# Altium schematic sheet BUS_Switch.SchDoc
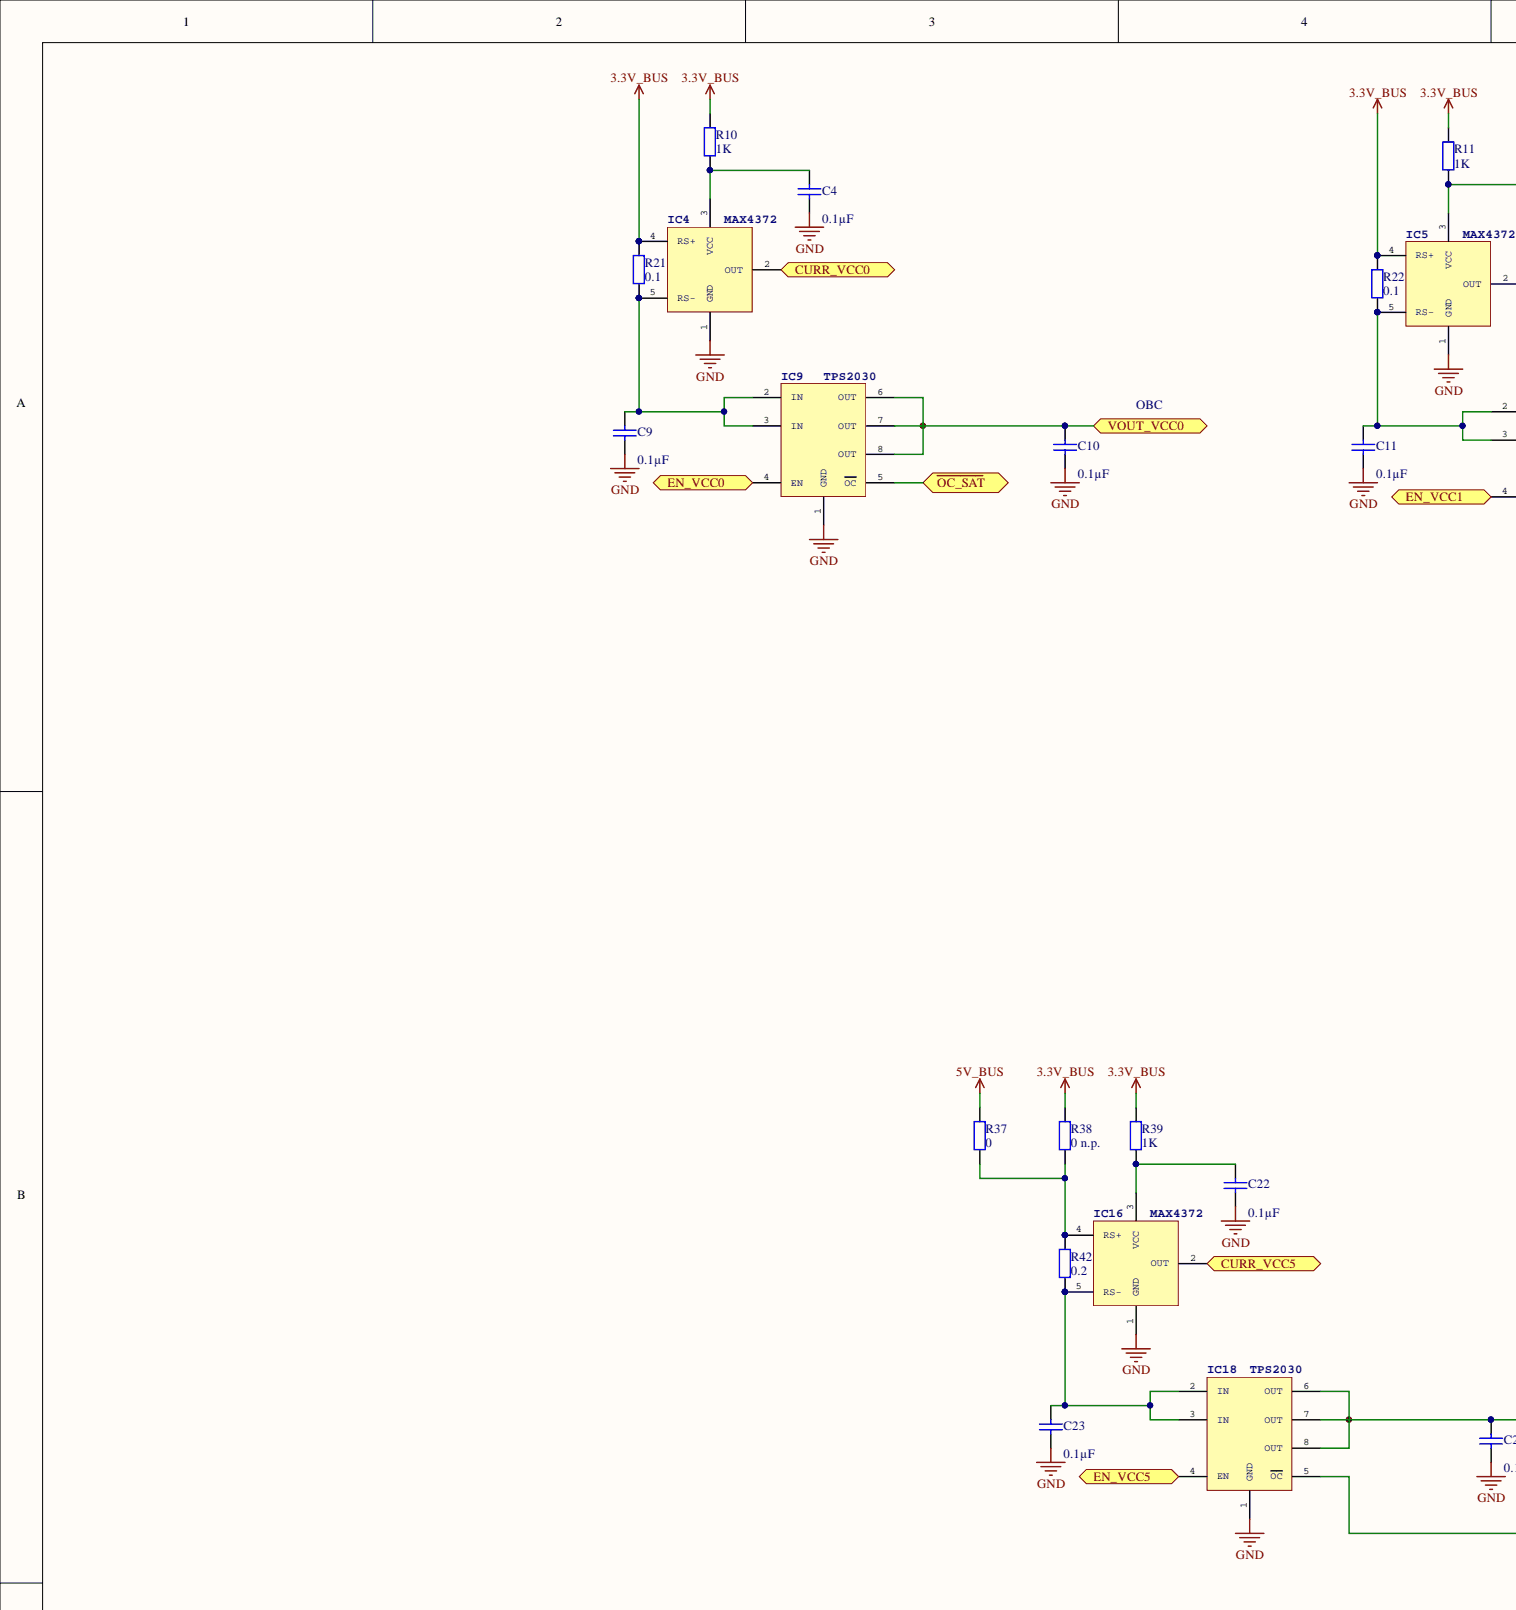
[diagram p0: BUS_Switch.SchDoc, top left]
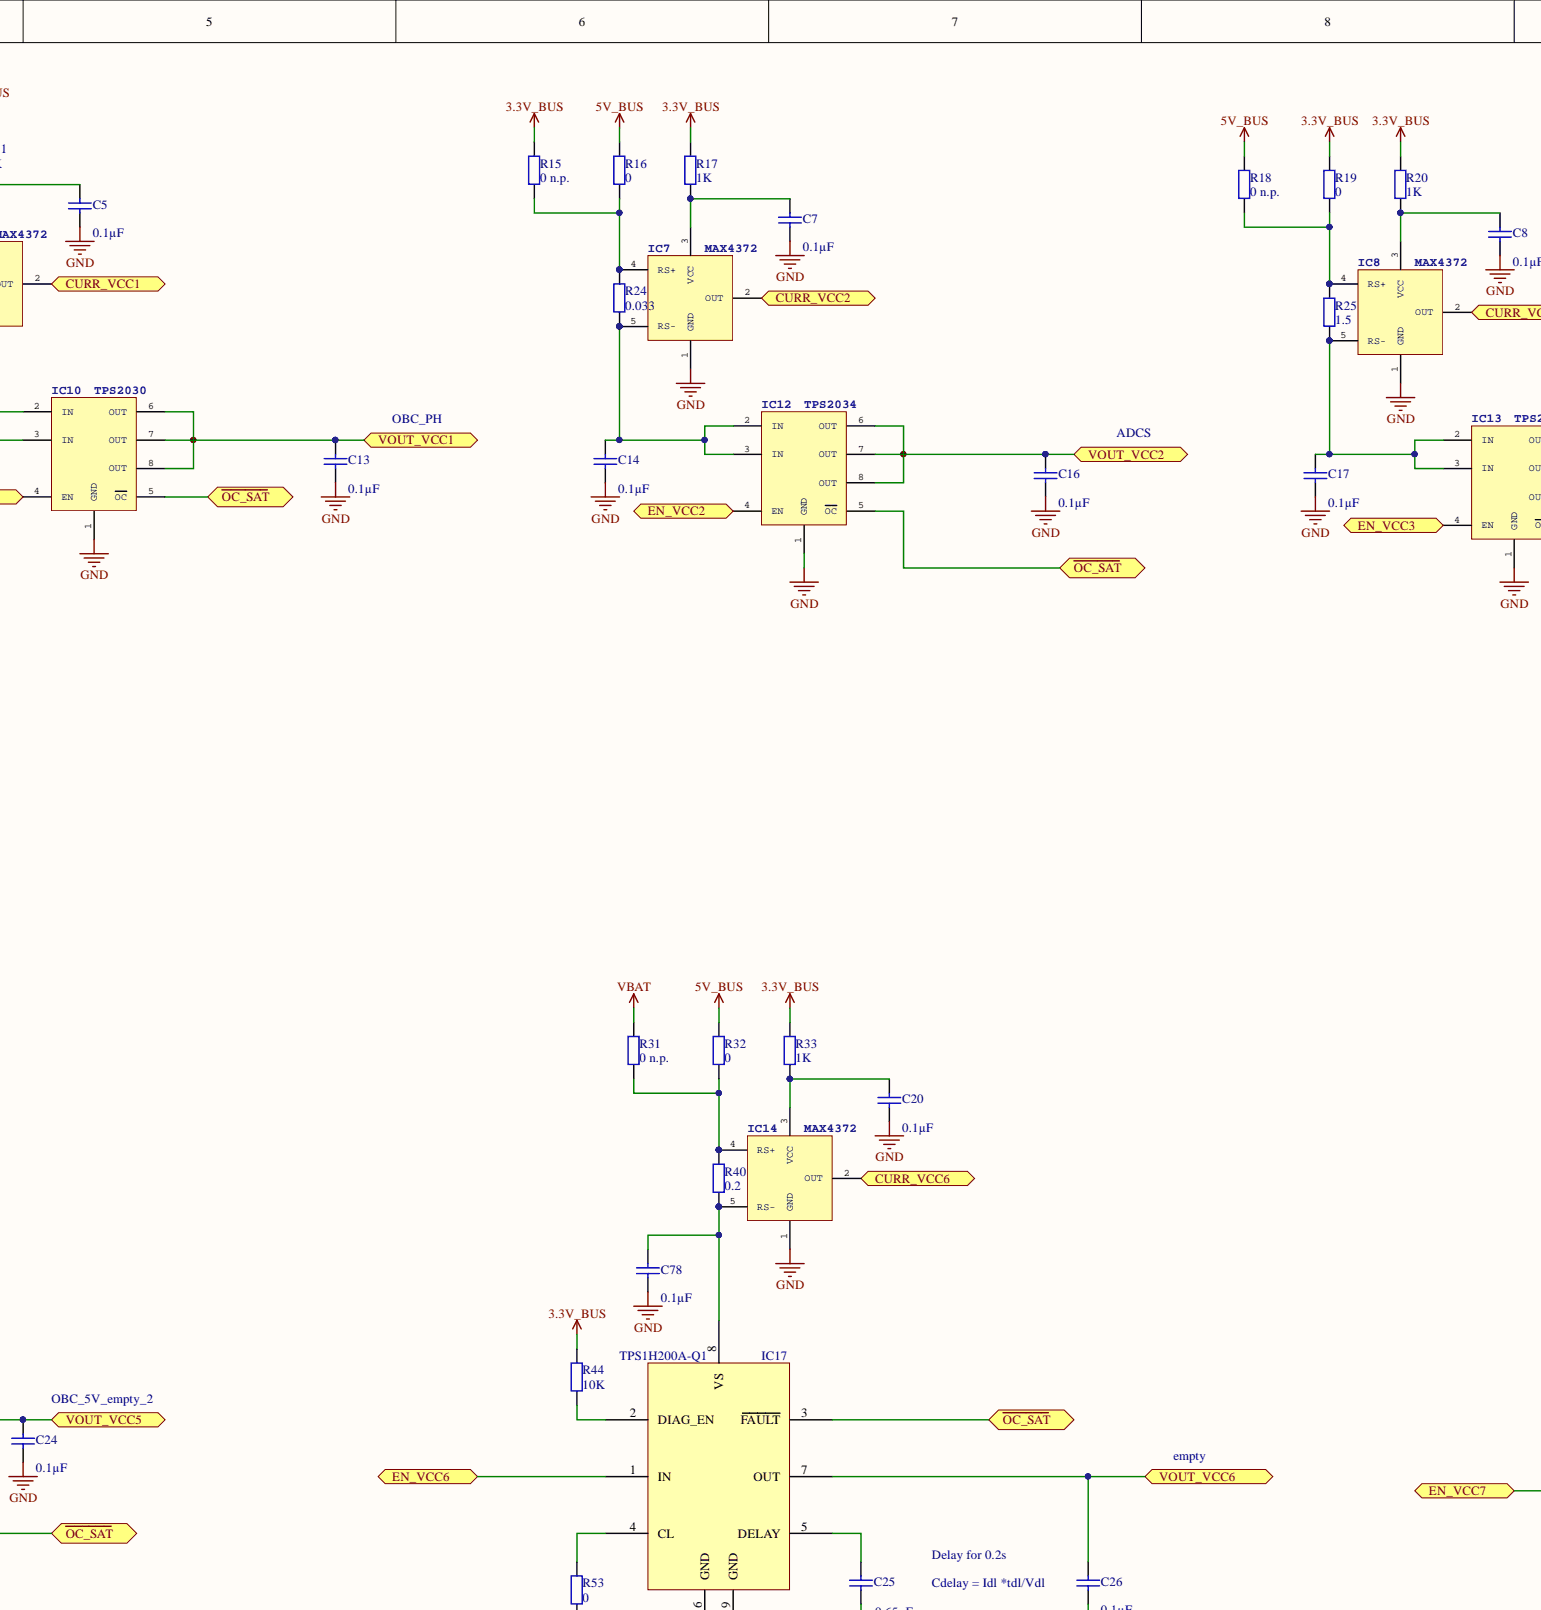
[diagram p1: BUS_Switch.SchDoc, top centre]
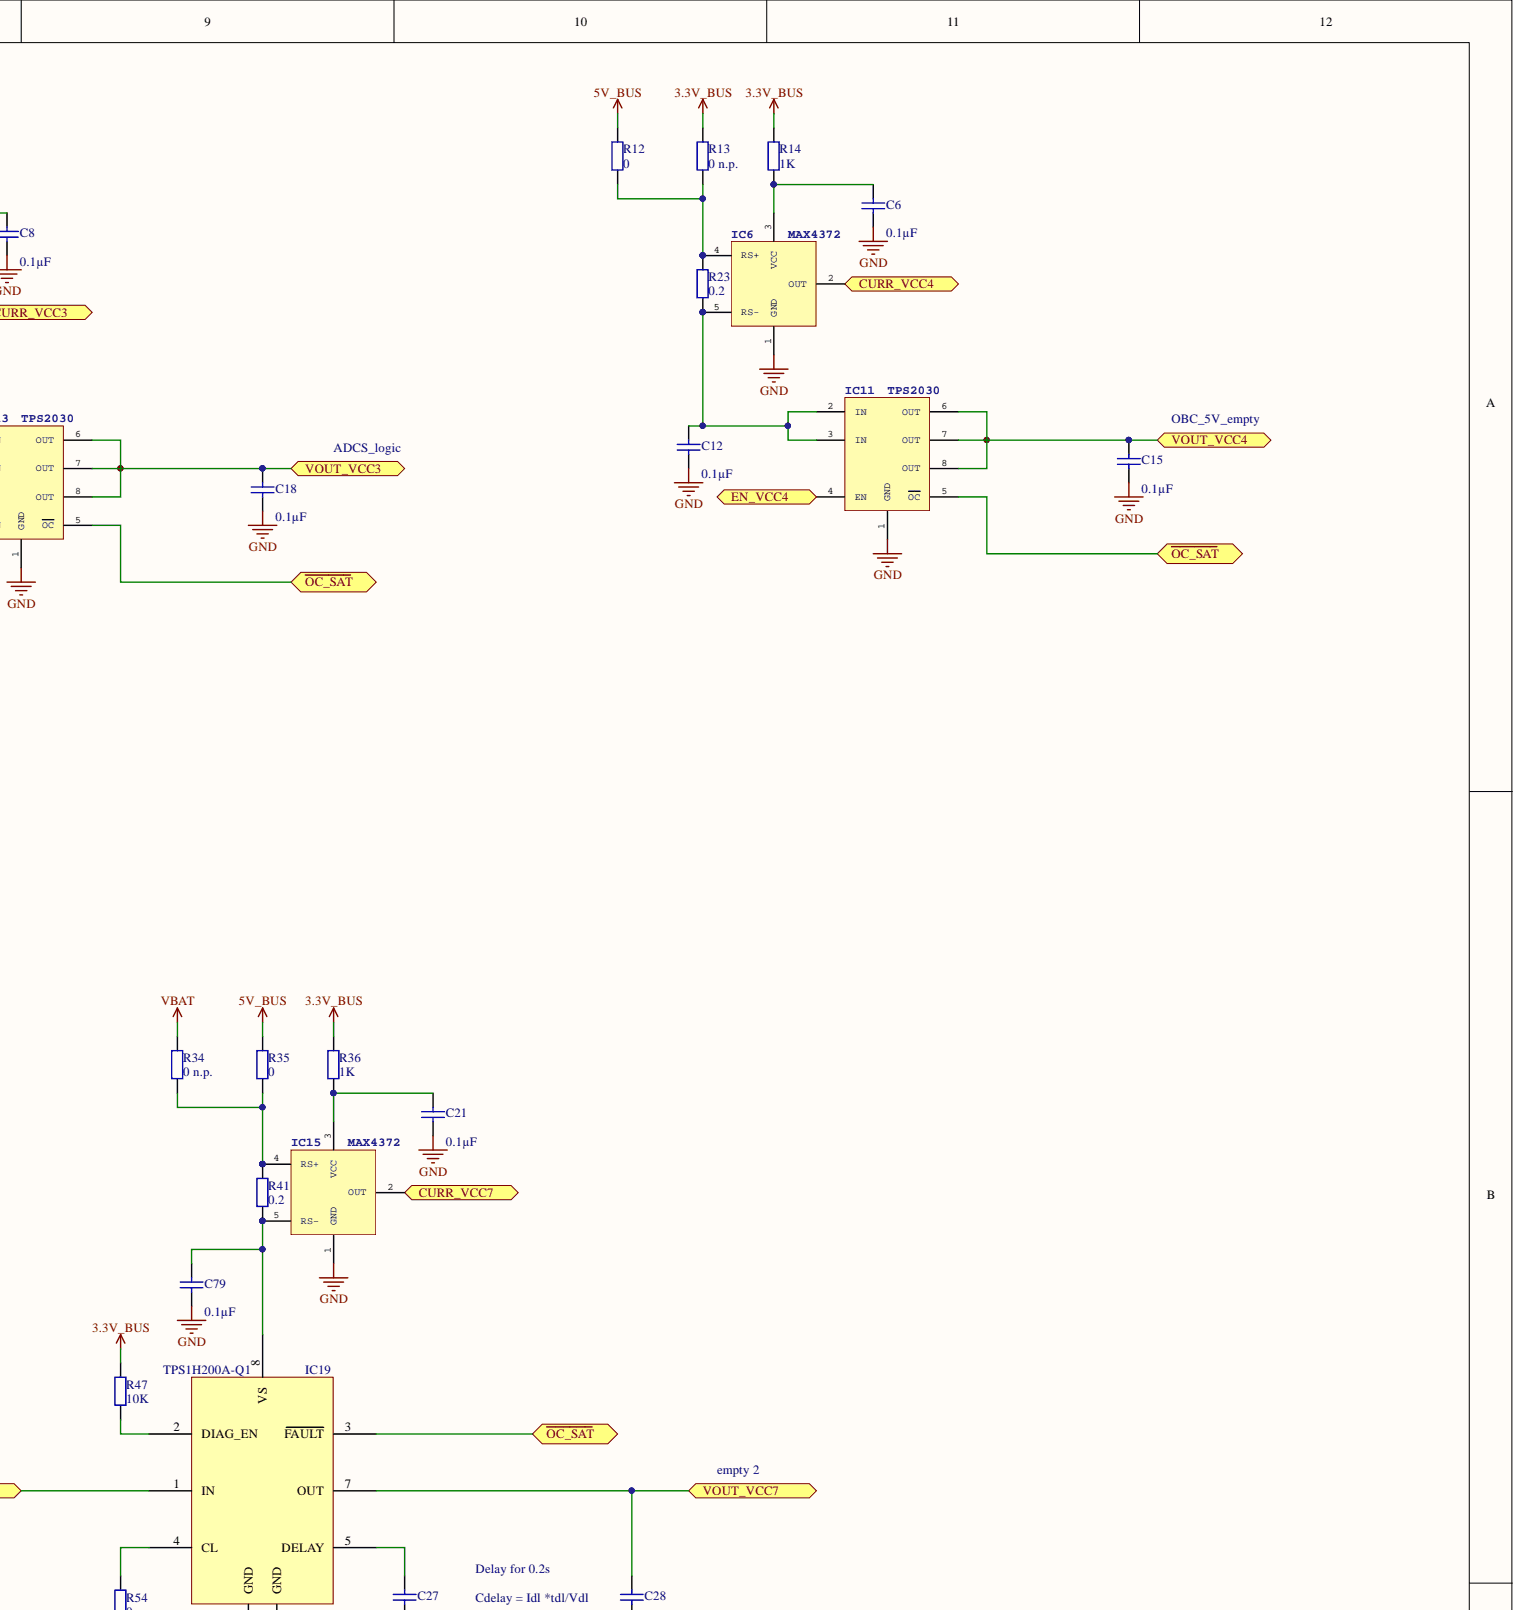
[diagram p2: BUS_Switch.SchDoc, top right]
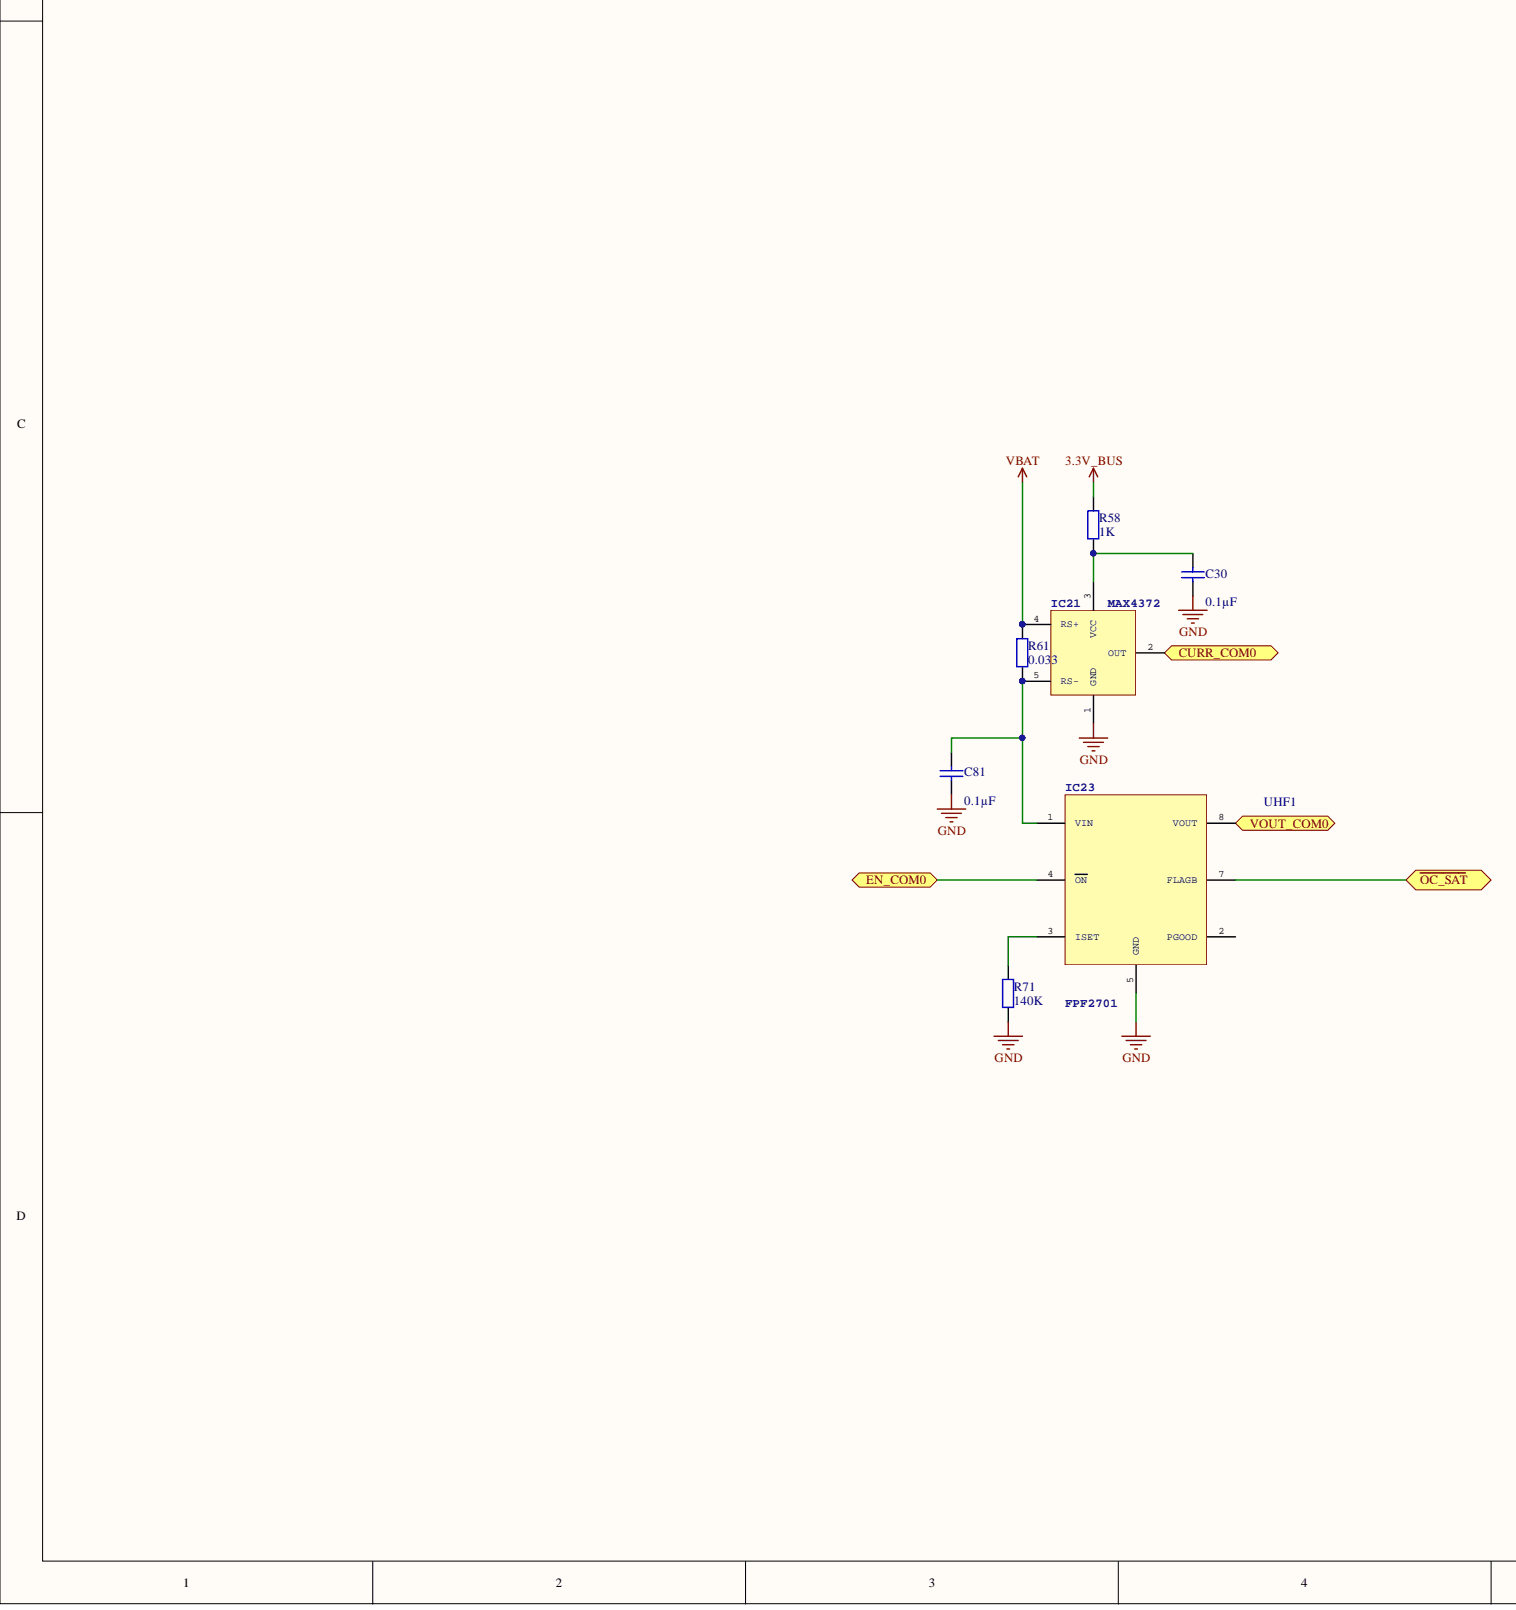
[diagram p3: BUS_Switch.SchDoc, bottom left]
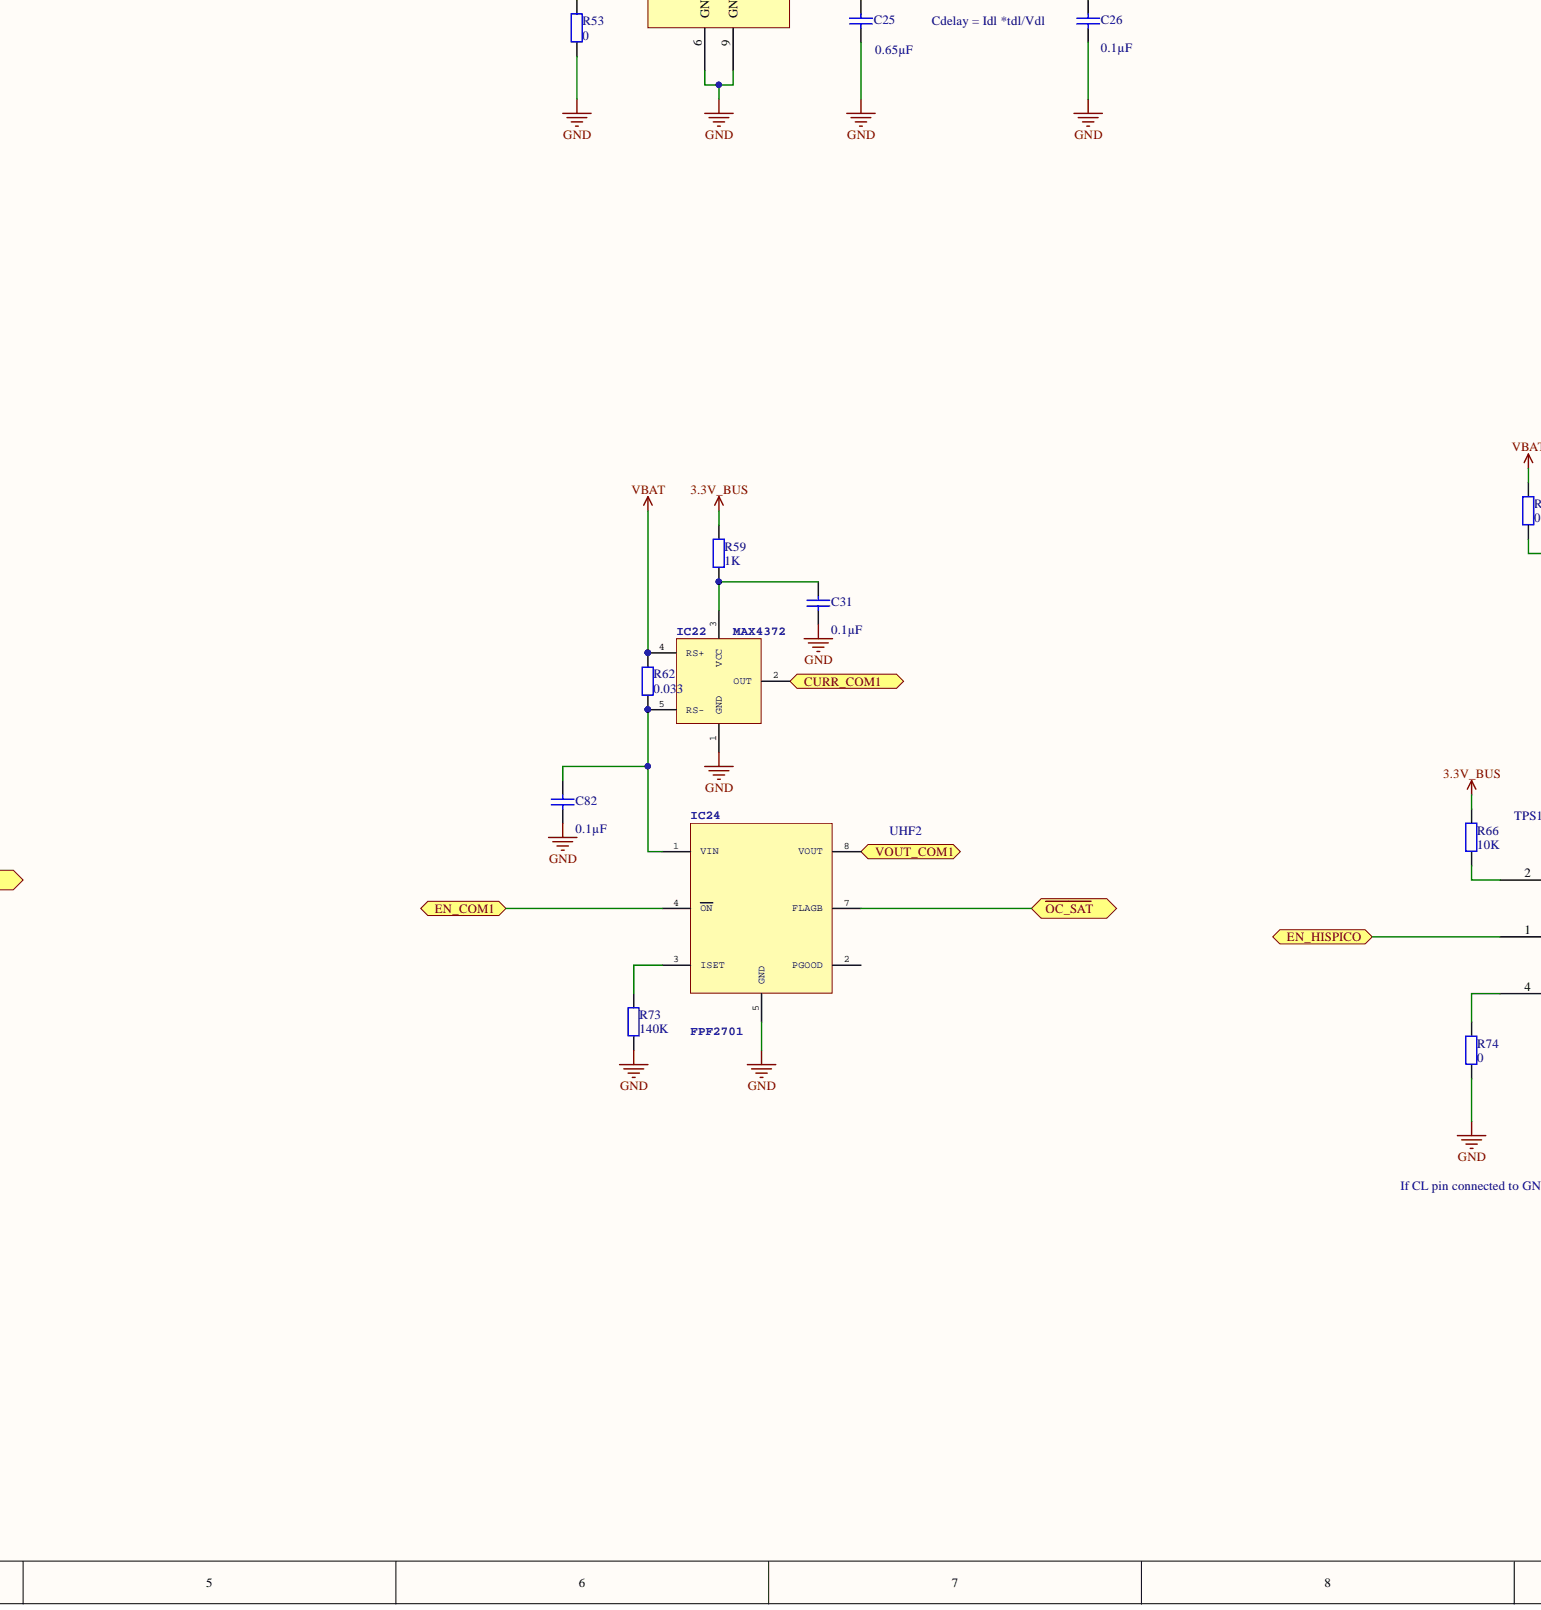
[diagram p4: BUS_Switch.SchDoc, bottom centre]
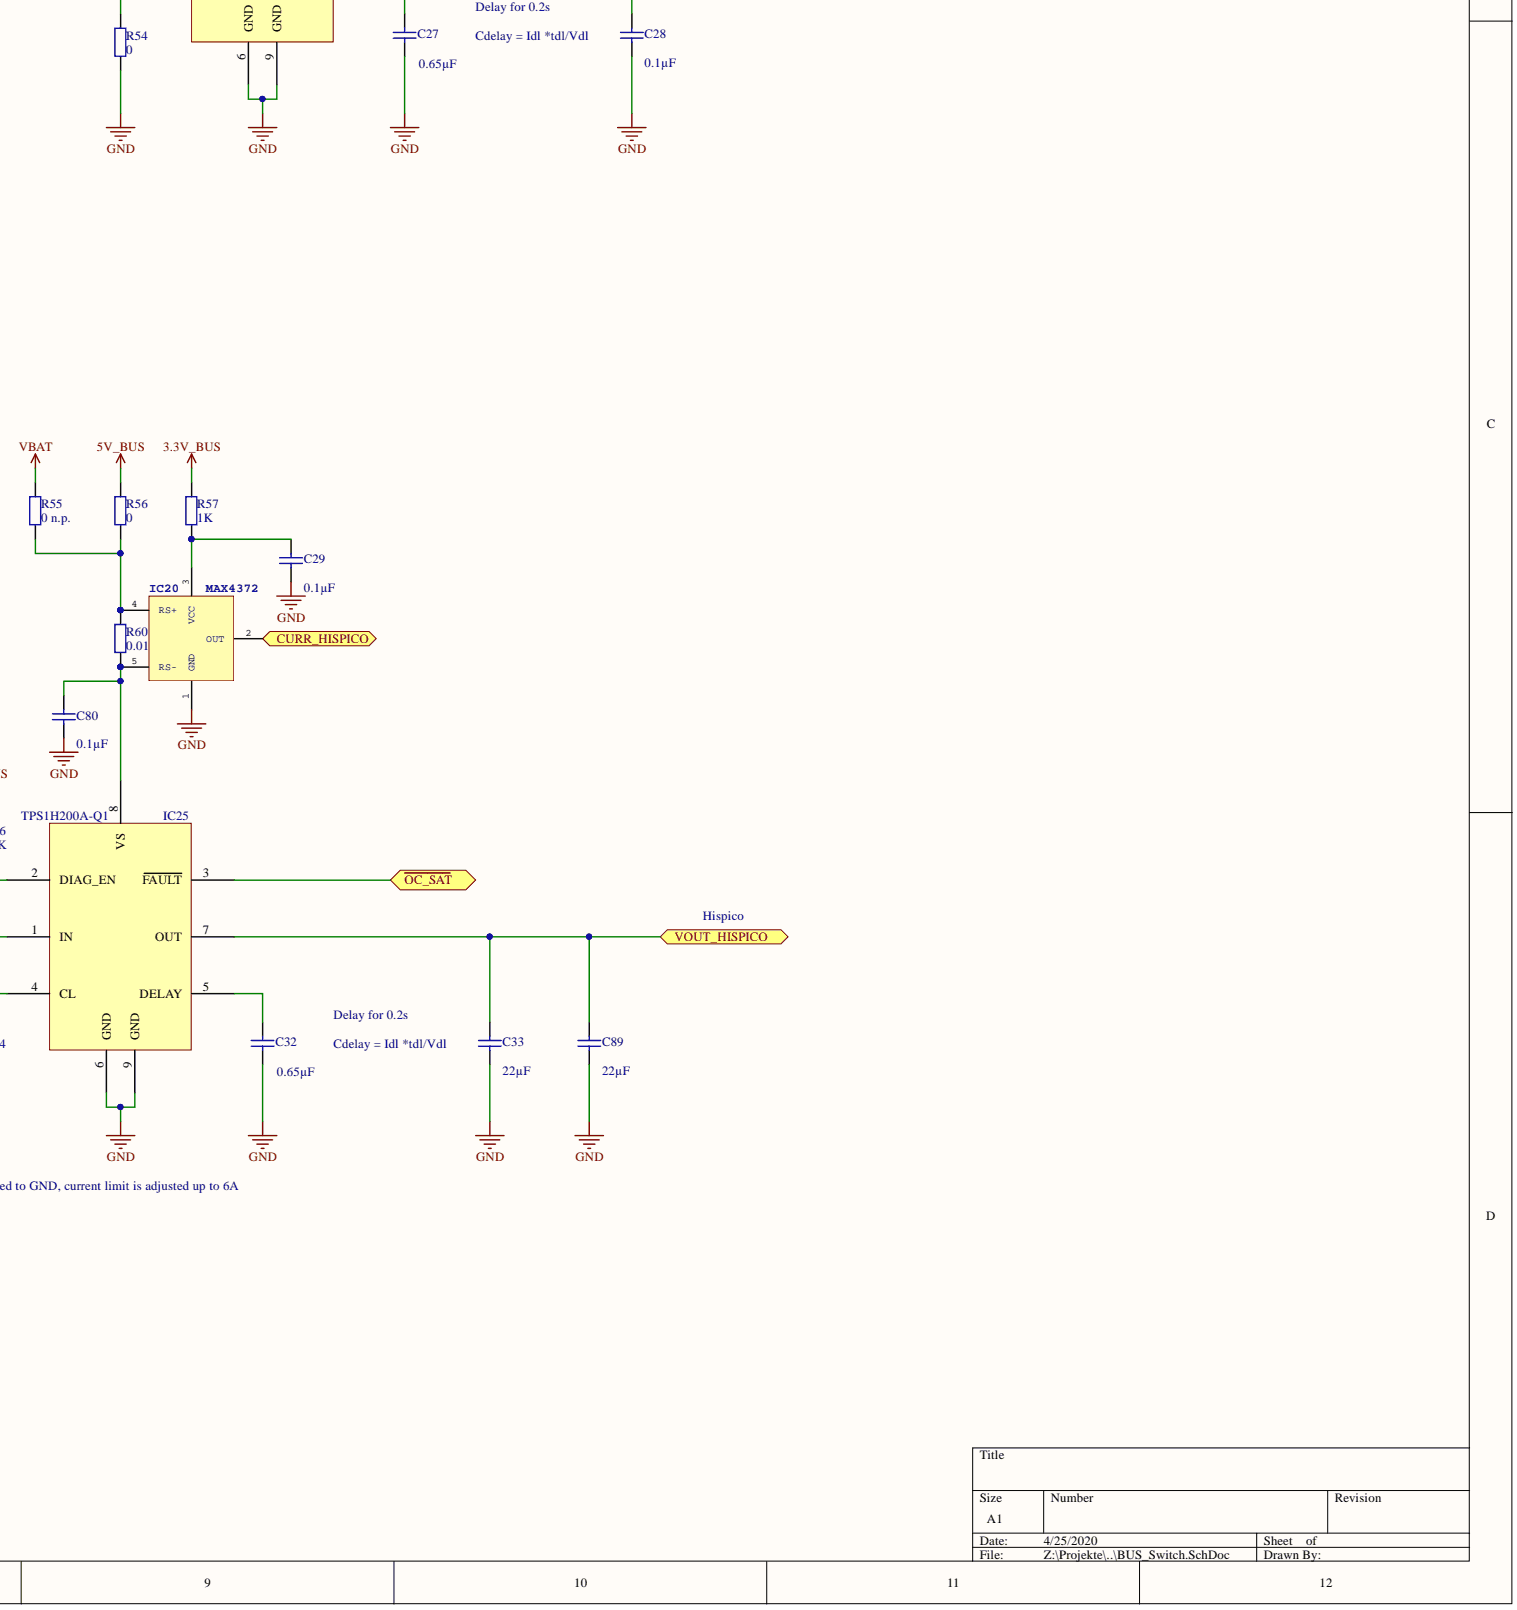
[diagram p5: BUS_Switch.SchDoc, bottom right]

## Parts
C4  0.1µF  [1608[0603]]
C5  0.1µF  [1608[0603]]
C6  0.1µF  [1608[0603]]
C7  0.1µF  [1608[0603]]
C8  0.1µF  [1608[0603]]
C9  0.1µF  [1608[0603]]
C10  0.1µF  [1608[0603]]
C11  0.1µF  [1608[0603]]
C12  0.1µF  [1608[0603]]
C13  0.1µF  [1608[0603]]
C14  0.1µF  [1608[0603]]
C15  0.1µF  [1608[0603]]
C16  0.1µF  [1608[0603]]
C17  0.1µF  [1608[0603]]
C18  0.1µF  [1608[0603]]
C20  0.1µF  [1608[0603]]
C21  0.1µF  [1608[0603]]
C22  0.1µF  [1608[0603]]
C23  0.1µF  [1608[0603]]
C24  0.1µF  [1608[0603]]
C25  0.65µF  [1608[0603]]
C26  0.1µF  [1608[0603]]
C27  0.65µF  [1608[0603]]
C28  0.1µF  [1608[0603]]
C29  0.1µF  [1608[0603]]
C30  0.1µF  [1608[0603]]
C31  0.1µF  [1608[0603]]
C32  0.65µF  [1608[0603]]
C33  value 22µF  [6-0805_N]  (hidden comment: 100µF)
C78  0.1µF  [1608[0603]]
C79  0.1µF  [1608[0603]]
C80  0.1µF  [1608[0603]]
C81  0.1µF  [1608[0603]]
C82  0.1µF  [1608[0603]]
C89  value 22µF  [6-0805_N]  (hidden comment: 100µF)
IC4  MAX4372  [SOT23-5L]
IC5  MAX4372  [SOT23-5L]
IC6  MAX4372  [SOT23-5L]
IC7  MAX4372  [SOT23-5L]
IC8  MAX4372  [SOT23-5L]
IC9  TPS2030  [SO08]
IC10  TPS2030  [SO08]
IC11  TPS2030  [SO08]
IC12  TPS2034  [SO08]
IC13  TPS2030  [SO08]
IC14  MAX4372  [SOT23-5L]
IC15  MAX4372  [SOT23-5L]
IC16  MAX4372  [SOT23-5L]
IC17  TPS1H200A-Q1  [SON65P300X100_HS-9N_SW]
IC18  TPS2030  [SO08]
IC19  TPS1H200A-Q1  [SON65P300X100_HS-9N_SW]
IC20  MAX4372  [SOT23-5L]
IC21  MAX4372  [SOT23-5L]
IC22  MAX4372  [SOT23-5L]
IC23  FPF2701  [SO08]
IC24  FPF2701  [SO08]
IC25  TPS1H200A-Q1  [SON65P300X100_HS-9N_SW]
R10  1K  [1608[0603]]
R11  1K  [1608[0603]]
R12  0  [6-0805_N]
R13  0 n.p.  [6-0805_N]
R14  1K  [1608[0603]]
R15  0 n.p.  [6-0805_N]
R16  0  [6-0805_N]
R17  1K  [1608[0603]]
R18  0 n.p.  [6-0805_N]
R19  0  [6-0805_N]
R20  1K  [1608[0603]]
R21  0.1  [1206]
R22  0.1  [1206]
R23  0.2  [1206]
R24  0.033  [1206]
R25  1.5  [1206]
R31  0 n.p.  [6-0805_N]
R32  0  [6-0805_N]
R33  1K  [1608[0603]]
R34  0 n.p.  [6-0805_N]
R35  0  [6-0805_N]
R36  1K  [1608[0603]]
R37  0  [6-0805_N]
R38  0 n.p.  [6-0805_N]
R39  1K  [1608[0603]]
R40  0.2  [1206]
R41  0.2  [1206]
R42  0.2  [1206]
R44  10K  [1608[0603]]
R47  10K  [1608[0603]]
R53  0  [1608[0603]]
R54  0  [1608[0603]]
R55  0 n.p.  [6-0805_N]
R56  0  [6-0805_N]
R57  1K  [1608[0603]]
R58  1K  [1608[0603]]
R59  1K  [1608[0603]]
R60  value 0.01  [1206]  (hidden comment: 0.07)
R61  value 0.033  [1206]  (hidden comment: 0.15)
R62  value 0.033  [1206]  (hidden comment: 0.15)
R66  10K  [1608[0603]]
R71  140K  [1608[0603]]
R73  140K  [1608[0603]]
R74  0  [1608[0603]]

## Ports
CURR_COM0, CURR_COM1, CURR_HISPICO, CURR_VCC0, CURR_VCC1, CURR_VCC2, CURR_VCC3, CURR_VCC4, CURR_VCC5, CURR_VCC6, CURR_VCC7, EN_COM0, EN_COM1, EN_HISPICO, EN_VCC0, EN_VCC1, EN_VCC2, EN_VCC3, EN_VCC4, EN_VCC5, EN_VCC6, EN_VCC7, VOUT_COM0, VOUT_COM1, VOUT_HISPICO, VOUT_VCC0, VOUT_VCC1, VOUT_VCC2, VOUT_VCC3, VOUT_VCC4, VOUT_VCC5, VOUT_VCC6, VOUT_VCC7, ~{OC_SAT}

## Nets
3.3V_BUS: IC4.4(RS+) IC5.4(RS+) R10.2 R11.2 R13.2 R14.2 R15.2 R17.2 R19.2 R20.2 R21.2 R22.2 R33.2 R36.2 R38.2 R39.2 R44.1 R47.1 R57.2 R58.2 R59.2 R66.1
5V_BUS: R12.2 R16.2 R18.2 R32.2 R35.2 R37.2 R56.2
GND: C4.1 C5.1 C6.1 C7.1 C8.1 C9.1 C10.1 C11.1 C12.1 C13.1 C14.1 C15.1 C16.1 C17.1 C18.1 C20.1 C21.1 C22.1 C23.1 C24.1 C25.1 C26.1 C27.1 C28.1 C29.1 C30.1 C31.1 C32.1 C33.1 C78.1 C79.1 C80.1 C81.1 C82.1 C89.1 IC4.1(GND) IC5.1(GND) IC6.1(GND) IC7.1(GND) IC8.1(GND) IC9.1(GND) IC10.1(GND) IC11.1(GND) IC12.1(GND) IC13.1(GND) IC14.1(GND) IC15.1(GND) IC16.1(GND) IC17.6(GND) IC17.9(GND) IC18.1(GND) IC19.6(GND) IC19.9(GND) IC20.1(GND) IC21.1(GND) IC22.1(GND) IC23.5(GND) IC24.5(GND) IC25.6(GND) IC25.9(GND) R53.1 R54.1 R71.1 R73.1 R74.1
VBAT: IC21.4(RS+) IC22.4(RS+) R31.2 R34.2 R55.2 R61.2 R62.2
CURR_COM0: IC21.2(OUT) ; port CURR_COM0
CURR_COM1: IC22.2(OUT) ; port CURR_COM1
CURR_HISPICO: IC20.2(OUT) ; port CURR_HISPICO
CURR_VCC0: IC4.2(OUT) ; port CURR_VCC0
CURR_VCC1: IC5.2(OUT) ; port CURR_VCC1
CURR_VCC2: IC7.2(OUT) ; port CURR_VCC2
CURR_VCC3: IC8.2(OUT) ; port CURR_VCC3
CURR_VCC4: IC6.2(OUT) ; port CURR_VCC4
CURR_VCC5: IC16.2(OUT) ; port CURR_VCC5
CURR_VCC6: IC14.2(OUT) ; port CURR_VCC6
CURR_VCC7: IC15.2(OUT) ; port CURR_VCC7
EN_COM0: IC23.4(ON) ; port EN_COM0
EN_COM1: IC24.4(ON) ; port EN_COM1
EN_HISPICO: IC25.1(IN) ; port EN_HISPICO
EN_VCC0: IC9.4(EN) ; port EN_VCC0
EN_VCC1: IC10.4(EN) ; port EN_VCC1
EN_VCC2: IC12.4(EN) ; port EN_VCC2
EN_VCC3: IC13.4(EN) ; port EN_VCC3
EN_VCC4: IC11.4(EN) ; port EN_VCC4
EN_VCC5: IC18.4(EN) ; port EN_VCC5
EN_VCC6: IC17.1(IN) ; port EN_VCC6
EN_VCC7: IC19.1(IN) ; port EN_VCC7
~{OC_SAT}: IC9.5(OC) IC10.5(OC) IC11.5(OC) IC12.5(OC) IC13.5(OC) IC17.3(FAULT) IC18.5(OC) IC19.3(FAULT) IC23.7(FLAGB) IC24.7(FLAGB) IC25.3(FAULT) ; port ~{OC_SAT}
VOUT_COM0: IC23.8(VOUT) ; port VOUT_COM0
VOUT_COM1: IC24.8(VOUT) ; port VOUT_COM1
VOUT_HISPICO: C33.2 C89.2 IC25.7(OUT) ; port VOUT_HISPICO
VOUT_VCC0: C10.2 IC9.6(OUT) IC9.7(OUT) IC9.8(OUT) ; port VOUT_VCC0
VOUT_VCC1: C13.2 IC10.6(OUT) IC10.7(OUT) IC10.8(OUT) ; port VOUT_VCC1
VOUT_VCC2: C16.2 IC12.6(OUT) IC12.7(OUT) IC12.8(OUT) ; port VOUT_VCC2
VOUT_VCC3: C18.2 IC13.6(OUT) IC13.7(OUT) IC13.8(OUT) ; port VOUT_VCC3
VOUT_VCC4: C15.2 IC11.6(OUT) IC11.7(OUT) IC11.8(OUT) ; port VOUT_VCC4
VOUT_VCC5: C24.2 IC18.6(OUT) IC18.7(OUT) IC18.8(OUT) ; port VOUT_VCC5
VOUT_VCC6: C26.2 IC17.7(OUT) ; port VOUT_VCC6
VOUT_VCC7: C28.2 IC19.7(OUT) ; port VOUT_VCC7
NetC4_2: C4.2 IC4.3(VCC) R10.1
NetC5_2: C5.2 IC5.3(VCC) R11.1
NetC6_2: C6.2 IC6.3(VCC) R14.1
NetC7_2: C7.2 IC7.3(VCC) R17.1
NetC8_2: C8.2 IC8.3(VCC) R20.1
NetC9_2: C9.2 IC4.5(RS-) IC9.2(IN) IC9.3(IN) R21.1
NetC11_2: C11.2 IC5.5(RS-) IC10.2(IN) IC10.3(IN) R22.1
NetC12_2: C12.2 IC6.5(RS-) IC11.2(IN) IC11.3(IN) R23.1
NetC14_2: C14.2 IC7.5(RS-) IC12.2(IN) IC12.3(IN) R24.1
NetC17_2: C17.2 IC8.5(RS-) IC13.2(IN) IC13.3(IN) R25.1
NetC20_2: C20.2 IC14.3(VCC) R33.1
NetC21_2: C21.2 IC15.3(VCC) R36.1
NetC22_2: C22.2 IC16.3(VCC) R39.1
NetC23_2: C23.2 IC16.5(RS-) IC18.2(IN) IC18.3(IN) R42.1
NetC25_2: C25.2 IC17.5(DELAY)
NetC27_2: C27.2 IC19.5(DELAY)
NetC29_2: C29.2 IC20.3(VCC) R57.1
NetC30_2: C30.2 IC21.3(VCC) R58.1
NetC31_2: C31.2 IC22.3(VCC) R59.1
NetC32_2: C32.2 IC25.5(DELAY)
NetC78_2: C78.2 IC14.5(RS-) IC17.8(VS) R40.1
NetC79_2: C79.2 IC15.5(RS-) IC19.8(VS) R41.1
NetC80_2: C80.2 IC20.5(RS-) IC25.8(VS) R60.1
NetC81_2: C81.2 IC21.5(RS-) IC23.1(VIN) R61.1
NetC82_2: C82.2 IC22.5(RS-) IC24.1(VIN) R62.1
NetIC6_4: IC6.4(RS+) R12.1 R13.1 R23.2
NetIC7_4: IC7.4(RS+) R15.1 R16.1 R24.2
NetIC8_4: IC8.4(RS+) R18.1 R19.1 R25.2
NetIC14_4: IC14.4(RS+) R31.1 R32.1 R40.2
NetIC15_4: IC15.4(RS+) R34.1 R35.1 R41.2
NetIC16_4: IC16.4(RS+) R37.1 R38.1 R42.2
NetIC17_2: IC17.2(DIAG_EN) R44.2
NetIC17_4: IC17.4(CL) R53.2
NetIC19_2: IC19.2(DIAG_EN) R47.2
NetIC19_4: IC19.4(CL) R54.2
NetIC20_4: IC20.4(RS+) R55.1 R56.1 R60.2
NetIC23_3: IC23.3(ISET) R71.2
NetIC24_3: IC24.3(ISET) R73.2
NetIC25_2: IC25.2(DIAG_EN) R66.2
NetIC25_4: IC25.4(CL) R74.2

Not connected: IC23 2(PGOOD); IC24 2(PGOOD)

## Library data of the parts
C4-C18 C20-C33 C78-C82 C89 = Cap: Capacitor; Component Kind: Standard; LatestRevisionDate: 17-Jul-2002; LatestRevisionNote: Re-released for DXP Platform.; Library Name: Miscellaneous Devices.IntLib; PackageDescription: Radial Cap, Thru-Hole; 2 Leads; 0.3 in Pin Spacing; PackageReference: RAD-0.3; Published: 8-Jun-2000; Signal Integrity: Cap; Simulation: CAP; Publisher: Altium Limited
  C4-C18 C20-C24 C26 C28-C31 C78-C82: Value: 0.1µF
  C25 C27 C32: Value: 0.65µF
IC9-IC13 IC18 = TPS203*D: Component Kind: Standard; Library Name: EPS.SCHLIB
R10-R25 R31-R42 R44 R47 R53-R62 R66 R71 R73 R74 = Res2: Resistor; Component Kind: Standard; LatestRevisionDate: 17-Jul-2002; LatestRevisionNote: Re-released for DXP Platform.; Library Name: Miscellaneous Devices.IntLib; PackageDescription: Axial Device, Thru-Hole; 2 Leads; 0.4 in Pin Spacing; PackageReference: AXIAL-0.4; Published: 8-Jun-2000; Signal Integrity: Res; Simulation: RESISTOR; Publisher: Altium Limited
  R10 R11 R14 R17 R20 R33 R36 R39 R57-R59: Value: 1K
  R12 R16 R19 R32 R35 R37 R53 R54 R56 R74: Value: 0
  R13 R15 R18 R31 R34 R38 R55: Value: 0 n.p.
  R21 R22: Value: 0.1
  R23 R40-R42: Value: 0.2
  R24: Value: 0.033
  R25: Value: 1.5
  R44 R47 R66: Value: 10K
  R71 R73: Value: 140K
IC4-IC8 IC14-IC16 IC20-IC22 = MAX4372: Component Kind: Standard; Library Name: EPS.SCHLIB
IC17 IC19 IC25 = TPS1H200A-Q1: Component Kind: Standard; Library Name: Battery_pack_pavel.SchLib
IC23 IC24 = FPF2701: Component Kind: Standard; Library Name: ICs_Pavel.SCHLIB
